# Altium schematic sheet voltage-detection.SchDoc
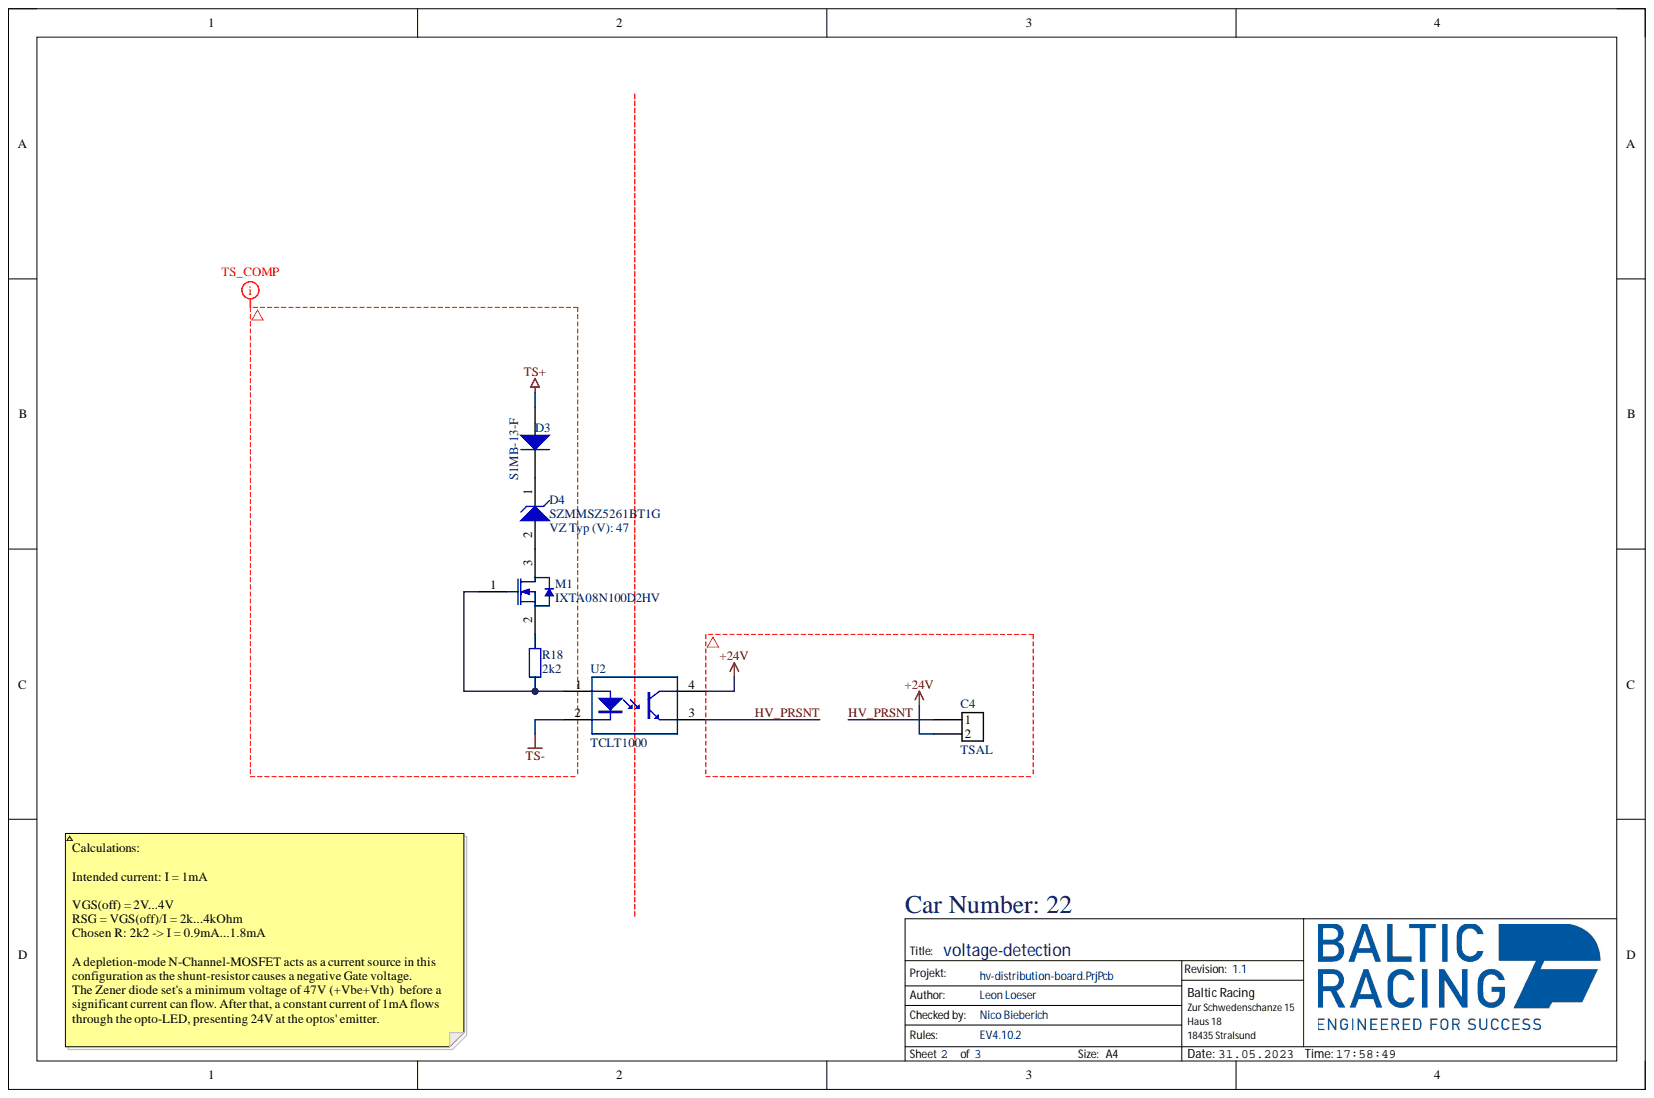
[diagram p0: voltage-detection.SchDoc]

## Parts
C4  TSAL  [43650-0200]
D3  S1MB-13-F  [DIOD-SMB-2_V]
D4  SZMMSZ5261BT1G  [ONSC-SOD-123-2-425-04_V]
M1  IXTA08N100D2HV  [IXYS_TO-263HV]
R18  value 2k2  [STACKPOLE CSR0603]  (hidden comment: SMD Resistor)
U2  TCLT1000  [VISH-SOP4L-4_V]

## Nets
+24V: C4.2 U2.4
TS+: D3.1
TS-: U2.2
HV_PRSNT: C4.1 U2.3
NetD3_2: D3.2 D4.1
NetD4_2: D4.2 M1.3
NetM1_1: M1.1 R18.1 U2.1
NetM1_2: M1.2 R18.2

## Library data of the parts
C4 = MicroFit3.0 2-Pin: Molex Micro-Fit 3.0 2-Pin  600V  8.5A; Component Kind: Standard; Library Name: Standardbauteile.IntLib
D3 = CMP-2000-07013-1: Surface Mount Glass Passivated Rectifier  , 1 A, 1.1 V, -65 to 150 degC, 2-Pin SMD, RoHS, Tape and Reel; Manufacturer: Diodes Inc; Average Rectified Current-Max: 1A; Forward Voltage: 1.1V; Peak Current-Max: 30A; Reverse Current-Max: 5µA; Reverse Voltage-Max [Vrrm]: 1000V; Component Kind: Standard; Datasheet URL: http://www.diodes.com/_files/datasheets/ds16003.pdf; Datasheet Version: Rev. 24-2, 12/2014; Library Name: Altium Content Vault; Manufacturer URL: http://www.diodes.com/; Mounting Technology: SurfaceMount; Package Description: 2-Pin Surface Mount Device, Body 4.315 x 3.62 mm; Package Reference: SMB-2
D4 = CMP-1060-00394-1: Zener Voltage Regulator, 500 mW, 2-Pin SOD-123, Pb-Free, Tape and Reel; Manufacturer: On Semiconductor; P Max (W): 0.5; VZ Typ (V): 47; Component Kind: Standard; ComponentLink1Description: Manufacturer URL; ComponentLink1URL: http://www.onsemi.com/; ComponentLink2Description: Datasheet; ComponentLink2URL: http://www.onsemi.cn/pub_link/Collateral/MMSZ5221BT1-D.PDF; ComponentLink3Description: Package Specification; ComponentLink3URL: http://www.onsemi.com/pub_link/Collateral/425-04.PDF; DatasheetVersion: Rev. 12; Library Name: Altium Content Vault; Mounting Technology: Surface Mount; PackageDescription: 2-Pin SOD, Body 1.6 x 2.69 mm; PackageReference: SOD-123-2-425-04; PackageVersion: Rev. G, 10/2009; Packing: Tape and Reel; PartNumber: SZMMSZ5261BT1G; RoHS: Pb-Free
M1 = IXTA08N100D2HV: D2 Series - 100V - 1700V N-Channel Depletion Mode Power MOSFETs, TO-263HV, RoHS; Manufacturer: Littelfuse; Category: MOSFETs; Component Kind: Standard; Manufacturer Part Number: IXTA08N100D2HV; Pricing 1: 1=1,74230, 300=2,14500, 500=1,90100, 1000=1,67700, 2000=1,66000, 2500=1,64400, 3000=1,62700, 4000=1,61100, 5000=1,59500, 10000=1,57900, 20000=1,56300 (USD); RoHS: Yes; Stock 1: 30; Supplier 1: Arrow Electronics; Supplier Part Number 1: IXTA08N100D2HV; Library Name: Leon.IntLib
R18 = SMD Resistor: Resistor with multiple footprints; Component Kind: Standard; Library Name: Standardbauteile.IntLib
U2 = CMP-2000-07234-1: Optocoupler, Phototransistor Output, VCEO 70 V, -55 to 100 degC, 4-Pin SOP (SOP4L), RoHS, Tape and Reel; Manufacturer: Vishay/Semiconductor; Input Type: DC; Maximum Collector Current: 50mA; Maximum Collector Emitter Saturation Voltage: 300mV; Maximum Collector Emitter Voltage: 70V; Maximum Current Transfer Ratio @ Current: 600%; Maximum Forward Voltage: 1.6V; Maximum Input Current: 60mA; Maximum Power Dissipation: 250mW; Maximum Reverse Voltage: 6V; Minimum Isolation Voltage: 5000Vrms; Number of Channels per Chip: 1; Typical Forward Voltage: 1.25V; Category: TransistorandPhotovoltaicOutputPhotoCouplers; Component Kind: Standard; Datasheet URL: http://www.vishay.com/docs/83515/tclt1000.pdf; Datasheet Version: Rev. 2.9, 12/2015; Library Name: Altium Content Vault; Manufacturer URL: http://www.vishay.com/; Mounting Technology: SurfaceMount; Operating Temperature: -40to100°C; Output Type: DC; Package: 4SOP; Package Description: 4-Pin Small Outline Package Integrated Circuit, Body 4.1 x 7.5 mm, Pitch 2.54 mm; Package Reference: SOP4L; Packaging: Tape&Reel
